# Altium schematic sheet power.SchDoc(power)
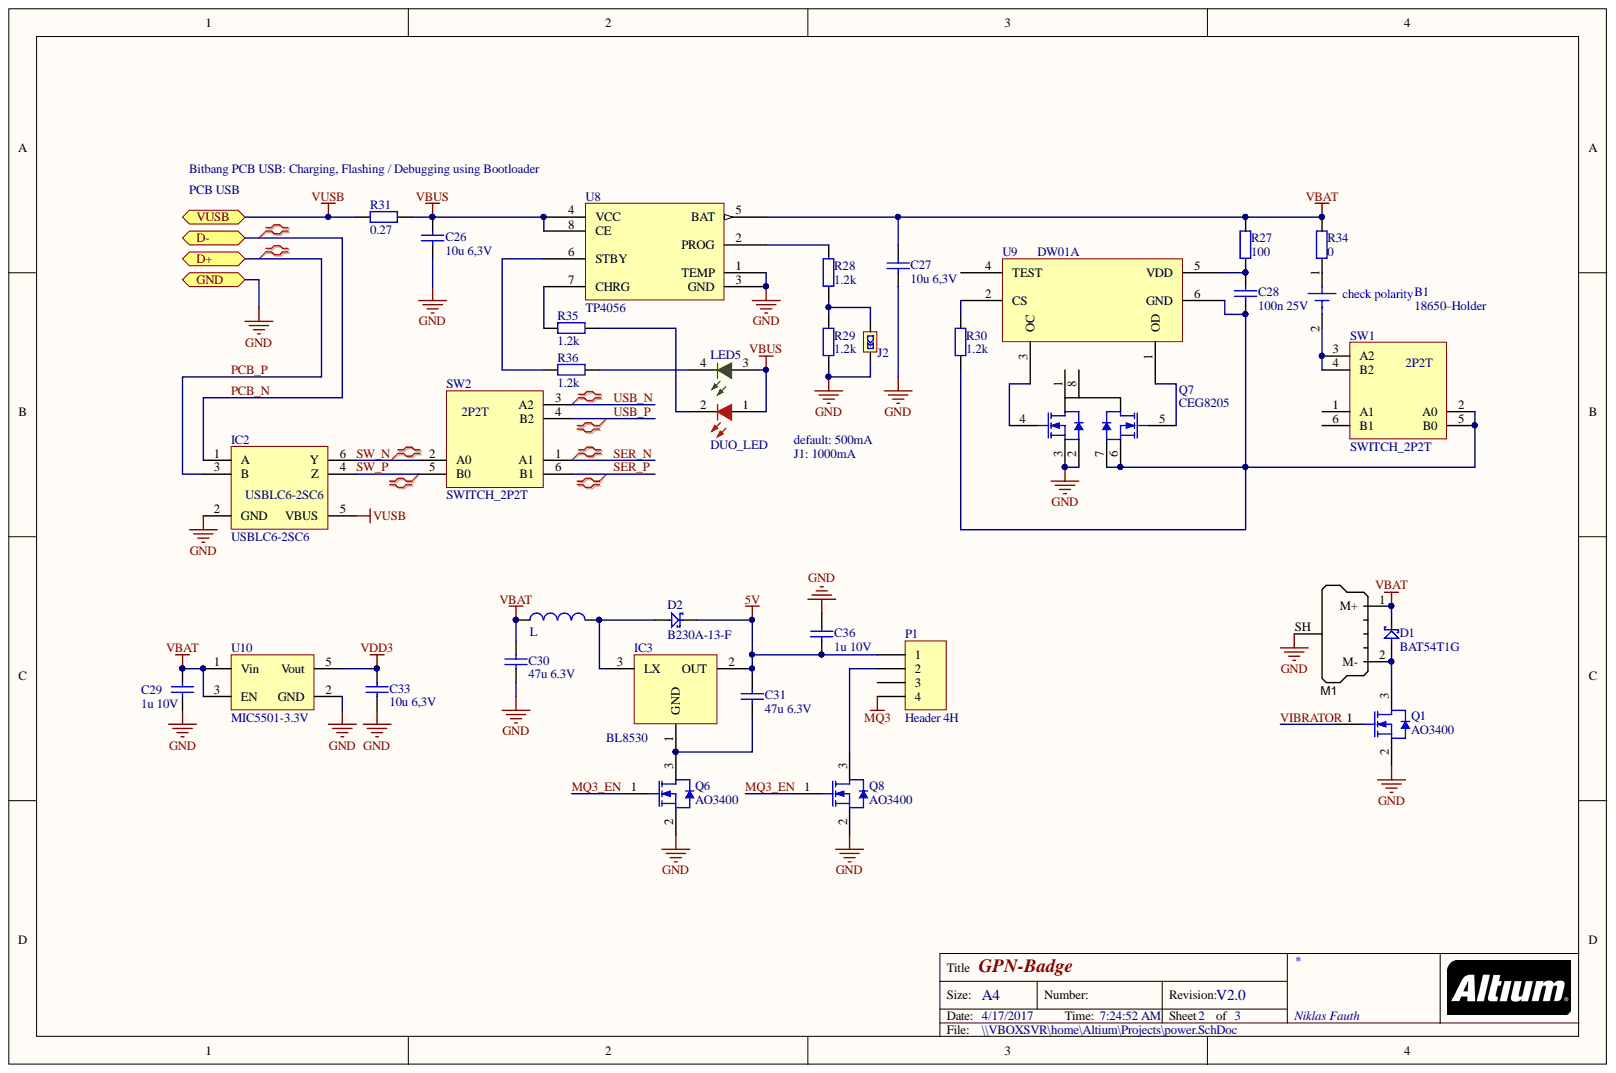
[diagram p0: power.SchDoc]

## Parts
*  L  [L]
B1  18650–Holder  [18650–Holder-SMD]
C26  10u 6,3V  [C-0603-H]
C27  10u 6,3V  [C-0603-H]
C28  100n 25V  [C-0603-M]
C29  1u 10V  [C-0603-M]
C30  47u 6.3V  [C-0805-M]
C31  47u 6.3V  [C-0805-M]
C33  10u 6,3V  [C-0603-H]
C36  1u 10V  [C-0603-M]
D1  BAT54T1G  [SOD123]
D2  B230A-13-F  [DIODE-SMA]
IC2  USBLC6-2SC6  [SOT23-6]
IC3  BL8530  [SOT89-150P409X160-3N]
J2  jumper  [JUMPER 1-1]  (value not drawn)
LED5  DUO_LED  [LEDDUO]
M1  VIBRATOR  [VIBRATOR_SMD]
P1  Header 4H  [HDR1X4H]
Q1  AO3400  [AO-SOT23-3_V]
Q6  AO3400  [AO-SOT23-3_V]
Q7  CEG8205  [SOP64P640X265-8N]
Q8  AO3400  [AO-SOT23-3_V]
R27  100  [R-0603-M]
R28  1.2k  [R-0603-M]
R29  1.2k  [R-0603-M]
R30  1.2k  [R-0603-M]
R31  0.27  [R-1206-M]
R34  0  [R-0603-M]
R35  1.2k  [R-0603-M]
R36  1.2k  [R-0603-M]
SW1  SWITCH_2P2T  [SWITCH_THT]
SW2  SWITCH_2P2T  [SWITCH_THT]
U8  TP4056  [SOIC127P600X20_HS-9N]
U9  DW01A  [SOT23-6]
U10  MIC5501-3.3V  [SOT23-5]

## Ports
D+, D-, GND, VUSB

## Nets
5V: C31.2 C36.2 D2.2 IC3.2 P1.1
MQ3: P1.4
VBAT: *.1 C27.2 C29.2 C30.2 D1.2 M1.1 R27.2 R34.2 U8.5(BAT) U10.1(Vin) U10.3(EN)
VBUS: C26.2 LED5.1 LED5.3 R31.2 U8.4(VCC) U8.8(CE)
VDD3: C33.2 U10.5(Vout)
GND: C26.1 C27.1 C29.1 C30.1 C33.1 C36.1 IC2.2(GND) J2.2 M1.SH Q1.2 Q6.2 Q7.2 Q7.3 Q8.2 R29.1 U8.1(TEMP) U8.3(GND) U10.2(GND) ; port GND
MQ3_EN: Q6.1 Q8.1
PCB_N: IC2.1(A)
PCB_P: IC2.3(B)
SER_N: SW2.1(A1)
SER_P: SW2.6(B1)
SW_N: IC2.6(Y) SW2.2(A0)
SW_P: IC2.4(Z) SW2.5(B0)
USB_N: SW2.3(A2)
USB_P: SW2.4(B2)
VIBRATOR: Q1.1
VUSB: IC2.5(VBUS) R31.1 ; port VUSB
Net*_2: *.2 D2.1 IC3.3
NetB1_1: B1.1 R34.1
NetB1_2: B1.2 SW1.3(A2) SW1.4(B2)
NetC28_1: C28.1 Q7.6 Q7.7 R30.1 SW1.2(A0) SW1.5(B0) U9.6(GND)
NetC28_2: C28.2 R27.1 U9.5(VDD)
NetC31_1: C31.1 IC3.1 Q6.3
NetD1_1: D1.1 M1.2 Q1.3
NetJ2_1: J2.1 R28.1 R29.2
NetLED5_2: LED5.2 R35.1
NetLED5_4: LED5.4 R36.1
NetP1_2: P1.2 Q8.3
NetQ7_4: Q7.4 U9.3(OC)
NetQ7_5: Q7.5 U9.1(OD)
NetR28_2: R28.2 U8.2(PROG)
NetR30_2: R30.2 U9.2(CS)
NetR35_2: R35.2 U8.7(CHRG)
NetR36_2: R36.2 U8.6(STBY)

Not connected: P1 3; Q7 1 8; SW1 1(A1) 6(B1); U9 4(TEST)

## Library data of the parts
C26 C27 C33 = C-0603-10u-6,3V: Capacitor 10uF 6,3V; Supplier Part Number 1: 80-C0603C106M9P; Supplier 1: Mouser; Library Name: Passives.IntLib; Component Kind: Standard
C28 = C-0603-100n-25V: Capacitor 100n 25V; Supplier Part Number 1: 80-C0603C104K3R; Supplier 1: Mouser; Library Name: Passives.IntLib; Component Kind: Standard
C29 C36 = C-0603-1u-10V: Capacitor 1uF 10V; Supplier Part Number 1: 80-C0603C105K8P; Supplier 1: Mouser; Library Name: Passives.IntLib; Component Kind: Standard
C30 C31 = C-0805-47u-6.3V: Capacitor 47u 6.3V; Supplier Part Number 1: 80-C0805C476M9P; Supplier 1: Mouser; Library Name: Passives.IntLib; Component Kind: Standard
D1 = D-BAT54T1G: Supplier Part Number 1: 863-BAT54T1G; Supplier 1: Mouser; Library Name: advanced.IntLib; Component Kind: Standard
D2 = D-B230A-13-F: Supplier Part Number 1: 621-B230A-F; Supplier 1: Mouser; Library Name: advanced.IntLib; Description: B230A-13-F; Component Kind: Standard
IC2 = USBLC6-2SC6: USB protection; Component Kind: Standard; Library Name: gpn-badge.IntLib
J2 = jumper 1-1: Library Name: advanced.SchLib; Component Kind: Standard
M1 = VIBRATOR: rawr; Component Kind: Standard; Library Name: gpn-badge.IntLib
P1 = Header 4H: Header, 4-Pin, Right Angle; Signal Integrity: Connector; LatestRevisionNote: Re-released for DXP Platform.; LatestRevisionDate: 17-Jul-2002; Component Kind: Standard; Publisher: Altium Limited; Library Name: gpn-badge.IntLib
Q1 Q6 Q8 = CMP-0278-00001-1: N-channel Enhancement Mode Field-Effect Transistor; 5.8A/30V; Manufacturer: Alpha and Omega; Junction Temperature: -55 to 150 degC; Gate-Source Voltage Limit: +/-12 V; Drain-Source Voltage Limit: 30 V; Channel Type: N; Storage Temperature: -55 to 150 degC; PartNumber: AO3400; PackageReference: SOT23; PackageDescription: 3-Pin SOT23, 3.016 x 2.8 mm Body, 0.95 mm Pitch; Mounting Technology: Surface Mount; Library Name: Altium Content Vault; DatasheetVersion: Rev.8, 12/2011; ComponentLink3URL: http://www.aosmd.com/res/packaging_information/SOT-23.pdf; ComponentLink3Description: Package Specification; ComponentLink2URL: http://www.aosmd.com/res/data_sheets/AO3400.pdf; ComponentLink2Description: Datasheet; ComponentLink1URL: http://www.aosmd.com/; ComponentLink1Description: Manufacturer URL; Component Kind: Standard
Q7 = MOSFET-CEG8205: Dual mini mosfet 20V 4.5A; Supplier 1: AliExpress; Component Kind: Standard; Library Name: gpn-badge.IntLib
R27 = R-0603-100: Resistor 100; Supplier Part Number 1: 71-CRCW0603-100-E3; Supplier 1: Mouser; Library Name: Passives.IntLib; Component Kind: Standard
R28-R30 R35 R36 = R-0603-1k2: Resistor 1.2k; Library Name: Passives.IntLib; Component Kind: Standard
R31 = R-1206-0R27: Resistor 0.27; Supplier Part Number 1: 71-RCWE1206R270FKEA; Supplier 1: Mouser; Library Name: Passives.IntLib; Component Kind: Standard
R34 = R-0603-0: Resistor 0; Supplier Part Number 1: 71-CRCW0603-0-E3; Supplier 1: Mouser; Resistance: 0; Library Name: Passives.IntLib; Component Kind: Standard
U8 = TP4056: 1A standalone linear charging IC; Supplier 1: AliExpress; Library Name: advanced.IntLib; Component Kind: Standard
U9 = DW01A: Single Cell LiIon LiPo Protection; Library Name: advanced.SchLib; Component Kind: Standard
* = L: Component Kind: Standard; Library Name: gpn-badge.IntLib
B1 = 18650–Holder: Component Kind: Standard; Library Name: gpn-badge.IntLib
IC3 = BL8530: Component Kind: Standard; Library Name: gpn-badge.IntLib
LED5 = DUO_LED: Component Kind: Standard; Library Name: gpn-badge.IntLib
SW1 SW2 = SWITCH_2P2T: Component Kind: Standard; Library Name: gpn-badge.IntLib
U10 = MIC5501-3.3V: Supplier Part Number 1: 998-MIC5501-3.0YM5TR; Supplier 1: Mouser; Library Name: advanced.IntLib; Component Kind: Standard
